# Altium schematic sheet [3] Sensor.SchDoc("[3] Sensor")
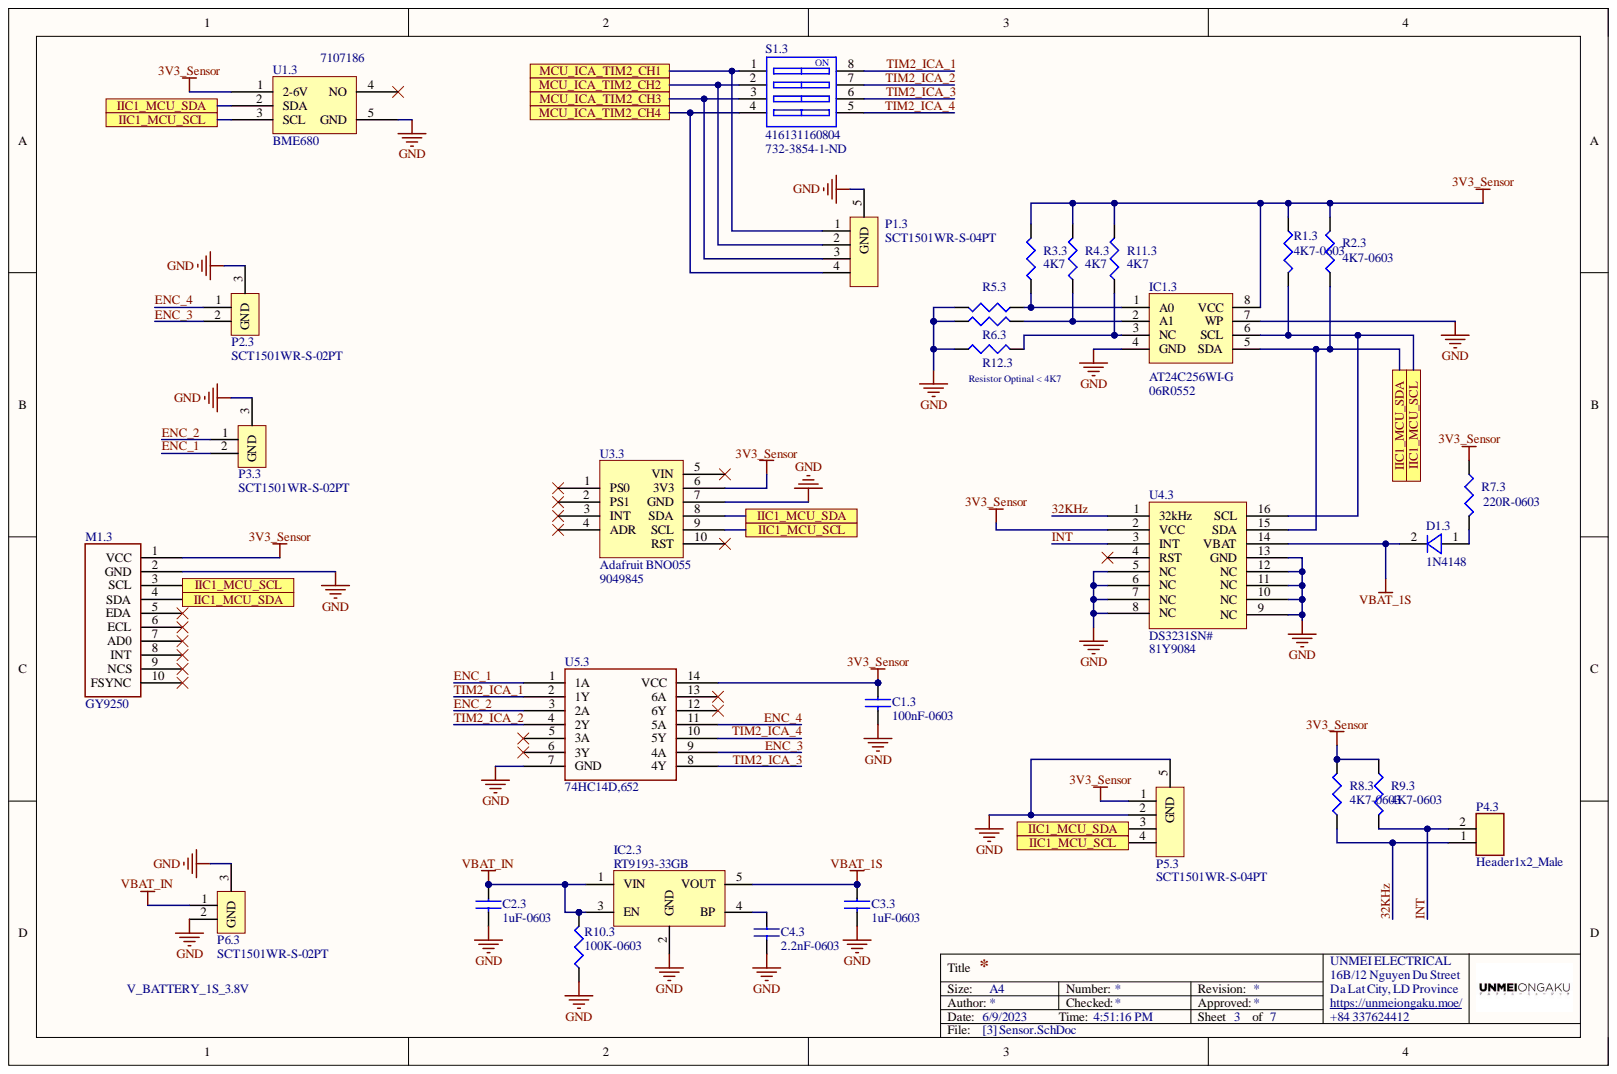
[diagram p0: [3] Sensor.SchDoc]

## Parts
C1.3  100nF-0603  [CAP0603]
C2.3  1uF-0603  [CAP0603]
C3.3  1uF-0603  [CAP0603]
C4.3  2.2nF-0603  [CAP0603]
D1.3  1N4148  [SOD-80]
IC1.3  AT24C256WI-G  Supplier Part Number 1: 06R0552  [SOIC-8]
IC2.3  RT9193-33GB  [SOT23-5]
M1.3  GY9250  [GY9250]
P1.3  SCT1501WR-S-04PT  [Connector ZH1.5 4P SMD]
P2.3  SCT1501WR-S-02PT  [Connector ZH1.5 2P SMD]
P3.3  SCT1501WR-S-02PT  [Connector ZH1.5 2P SMD]
P4.3  Header1x2_Male  [HDR1x2_2.54_MALE]
P5.3  SCT1501WR-S-04PT  [Connector ZH1.5 4P SMD]
P6.3  SCT1501WR-S-02PT  [Connector ZH1.5 2P SMD]
R1.3  4K7-0603  [RES0603 - 3D]
R2.3  4K7-0603  [RES0603 - 3D]
R3.3  4K7  [RES0603 - 3D]
R4.3  4K7  [RES0603 - 3D]
R5.3  70R  [RES0805]  (value not drawn)
R6.3  70R  [RES0805]  (value not drawn)
R7.3  220R-0603  [RES0603 - 3D]
R8.3  4K7-0603  [RES0603 - 3D]
R9.3  4K7-0603  [RES0603 - 3D]
R10.3  100K-0603  [RES0603 - 3D]
R11.3  4K7  [RES0603 - 3D]
R12.3  0RP  [RES0805]  (value not drawn)
S1.3  416131160804  Supplier Part Number 1: 732-3854-1-ND  [DIP Switches SMD 1.27mm 4P]
U1.3  BME680  Supplier Part Number 1: 7107186  [BME680]
U3.3  Adafruit BNO055  Manufacturer Part Number: BNO055  Supplier Part Number 1: 9049845  [Adafruit BNO055]
U4.3  DS3231SN#  Supplier Part Number 1: 81Y9084  [SOIC-16W]
U5.3  74HC14D,652  [SOT108-1_NEX]

## Ports
IIC1_MCU_SCL, IIC1_MCU_SDA, MCU_ICA_TIM2_CH1, MCU_ICA_TIM2_CH2, MCU_ICA_TIM2_CH3, MCU_ICA_TIM2_CH4

## Nets
3V3_Sensor: C1.3.1 IC1.3.8(VCC) M1.3.1(VCC) P5.3.1 R1.3.1 R2.3.2 R3.3.2 R4.3.2 R7.3.1 R8.3.2 R9.3.1 R11.3.2 U1.3.1(2-6V) U3.3.6(3V3) U4.3.2(VCC) U5.3.14(VCC)
GND: C1.3.2 C2.3.2 C3.3.2 C4.3.2 IC1.3.4(GND) IC1.3.7(WP) IC2.3.2(GND) M1.3.2(GND) P1.3.5(GND) P2.3.3 P3.3.3 P5.3.2 P5.3.5(GND) P6.3.2 P6.3.3 R5.3.2 R6.3.1 R10.3.1 R12.3.1 U1.3.5(GND) U3.3.7(GND) U4.3.5(NC) U4.3.6(NC) U4.3.7(NC) U4.3.8(NC) U4.3.9(NC) U4.3.10(NC) U4.3.11(NC) U4.3.12(NC) U4.3.13(GND) U5.3.7(GND)
VBAT_1S: C3.3.1 D1.3.2 IC2.3.5(VOUT) U4.3.14(VBAT)
VBAT_IN: C2.3.1 IC2.3.1(VIN) IC2.3.3(EN) P6.3.1 R10.3.2
32KHz: P4.3.1 R8.3.1 U4.3.1(32kHz)
ENC_1: P3.3.2 U5.3.1(1A)
ENC_2: P3.3.1 U5.3.3(2A)
ENC_3: P2.3.2 U5.3.9(4A)
ENC_4: P2.3.1 U5.3.11(5A)
IIC1_MCU_SCL: IC1.3.6(SCL) M1.3.3(SCL) P5.3.4 R1.3.2 U1.3.3(SCL) U3.3.9(SCL) U4.3.16(SCL) ; port IIC1_MCU_SCL
IIC1_MCU_SDA: IC1.3.5(SDA) M1.3.4(SDA) P5.3.3 R2.3.1 U1.3.2(SDA) U3.3.8(SDA) U4.3.15(SDA) ; port IIC1_MCU_SDA
INT: P4.3.2 R9.3.2 U4.3.3(INT)
MCU_ICA_TIM2_CH1: P1.3.1 S1.3.1 ; port MCU_ICA_TIM2_CH1
MCU_ICA_TIM2_CH2: P1.3.2 S1.3.2 ; port MCU_ICA_TIM2_CH2
MCU_ICA_TIM2_CH3: P1.3.3 S1.3.3 ; port MCU_ICA_TIM2_CH3
MCU_ICA_TIM2_CH4: P1.3.4 S1.3.4 ; port MCU_ICA_TIM2_CH4
TIM2_ICA_1: S1.3.8 U5.3.2(1Y)
TIM2_ICA_2: S1.3.7 U5.3.4(2Y)
TIM2_ICA_3: S1.3.6 U5.3.8(4Y)
TIM2_ICA_4: S1.3.5 U5.3.10(5Y)
NetC4.3_1: C4.3.1 IC2.3.4(BP)
NetD1.3_1: D1.3.1 R7.3.2
NetIC1.3_1: IC1.3.1(A0) R3.3.1 R5.3.1
NetIC1.3_2: IC1.3.2(A1) R4.3.1 R6.3.2
NetIC1.3_3: IC1.3.3(NC) R11.3.1 R12.3.2

Not connected: M1.3 5(EDA) 6(ECL) 7(AD0) 8(INT) 9(NCS) 10(FSYNC); U1.3 4(NO); U3.3 1(PS0) 2(PS1) 3(INT) 4(ADR) 5(VIN) 10(RST); U4.3 4(RST); U5.3 5(3A) 6(3Y) 12(6Y) 13(6A)

## Library data of the parts
C1.3 = CC0603KRX7R9BB104: CAP CER 0.1UF 50V X7R 0603; Manufacturer 1: Yageo; Capacitance: 0.1µF; Category 1: Capacitors; Tolerance: ±10%; Value: 100nF; Applications: General Purpose; Component Kind: Standard; ComponentLink1Description: http://www.yageo.com/documents/recent/UPY-GPHC_X7R_6.3V-to-50V_18.pdf; ComponentLink1URL: http://www.yageo.com/documents/recent/UPY-GPHC_X7R_6.3V-to-50V_18.pdf; Description 1: CAP CER 0.1UF 50V X7R 0603; Failure Rate: -; Features: -; Height - Seated (Max): -; Lead Spacing: -; Lead Style: -; Manufacturer Part Number 1: CC0603KRX7R9BB104; Mounting Type: Surface Mount, MLCC; Operating Temperature: -55°C ~ 125°C; Package / Case: 0603 (1608 Metric); Packaging: Cut Tape (CT); Part Status: Active; Pricing 1: 1=0.1, 10=0.031, 100=0.014, 500=0.00998, 1000=0.00783 (USD); Ratings: -; RoHS 1: RoHS Compliant; Size / Dimension: 0.063" L x 0.031" W (1.60mm x 0.80mm); Stock 1: 4411645; Supplier 1: Digi-Key; Supplier Part Number 1: 311-1344-1-ND; Temperature Coefficient: X7R; Thickness (Max): 0.035" (0.90mm); Voltage - Rated: 50V; Library Name: Minh_Lib.SchLib
C2.3 C3.3 = CC0603KRX7R7BB105: CAP CER 1UF 16V X7R 0603; Manufacturer 1: Yageo; Capacitance: 1µF; Category 1: Capacitors; Tolerance: ±10%; Applications: General Purpose; Component Kind: Standard; ComponentLink1Description: http://www.yageo.com/documents/recent/UPY-GPHC_X7R_6.3V-to-50V_18.pdf; ComponentLink1URL: http://www.yageo.com/documents/recent/UPY-GPHC_X7R_6.3V-to-50V_18.pdf; Description 1: CAP CER 1UF 16V X7R 0603; Failure Rate: -; Features: -; Height - Seated (Max): -; Lead Spacing: -; Lead Style: -; Manufacturer Part Number 1: CC0603KRX7R7BB105; Mounting Type: Surface Mount, MLCC; Operating Temperature: -55°C ~ 125°C; Package / Case: 0603 (1608 Metric); Packaging: Cut Tape (CT); Part Status: Active; Pricing 1: 1=0.1, 10=0.051, 100=0.0227, 500=0.0162, 1000=0.01274 (USD); Ratings: -; RoHS 1: RoHS Compliant; Size / Dimension: 0.063" L x 0.031" W (1.60mm x 0.80mm); Stock 1: 274580; Supplier 1: Digi-Key; Supplier Part Number 1: 311-1446-1-ND; Temperature Coefficient: X7R; Thickness (Max): 0.035" (0.90mm); Value: 1uF; Voltage - Rated: 16V; Library Name: Minh_Lib.SchLib
C4.3 = CC0603KRX7R9BB222: Multilayer Ceramic Capacitors MLCC - SMD/SMT 2200PF 50V 10% 0603; Brand: Yageo; Capacitance - nF: 2.2 nF; Capacitance - uF: 0.0022 uF; Class: Class 2; Dielectric: X7R; Type: General Purpose and High Capacitance Surface Mount Ceramic Multilayer Capacitor; Value: 2.2nF; Voltage Rating DC: 50 VDC; Capacitance: 2200 pF; Case Code - in: 0603; Case Code - mm: 1608; Category: Multilayer Ceramic Capacitors MLCC - SMD/SMT; Component Kind: Standard; ComponentLink1Description: https://www.mouser.com/datasheet/2/447/UPY-GPHC_X7R_6.3V-to-50V_18-1154002.pdf; ComponentLink1URL: https://www.mouser.com/datasheet/2/447/UPY-GPHC_X7R_6.3V-to-50V_18-1154002.pdf; Height: 0.8 mm; Length: 1.6 mm; Manufacturer: Yageo; Manufacturer Part Number: CC0603KRX7R9BB222; Maximum Operating Temperature: + 125 C; Minimum Operating Temperature: - 55 C; Minimum Order Quantity: 1; Package / Case: 0603 (1608 metric); Packaging: Cut Tape; Pricing 1: 1=0.100, 10=0.090, 100=0.070, 1000=0.065, 4000=0.010, 8000=0.009 (USD); Product: General Type MLCCs; Product Type: Ceramic Capacitors; Reeling: True; RoHS: RoHS Compliant; RoHS - Mouser: Y; Series: CC; Standard Pack Qty: 4000; Stock 1: 167669; Subcategory: Capacitors; Supplier 1: Mouser; Supplier Part Number 1: 603-C0603KRX7R9BB222; Termination: Standard; Termination Style: SMD/SMT; Tolerance: 10 %; Width: 0.8 mm; Library Name: Minh_Lib.SchLib
D1.3 = 1N4148: Rectifier Diode Small Signal Switching 100V 0.2A 4ns Automotive 2-Pin DO-35 Ammo; Manufacturer: NTE Electronics; Capacitance: 4pF; Current Rating: 200mA; Element Configuration: Single; Forward Current: 300mA; Forward Voltage: 1V; Max Forward Surge Current (Ifsm): 1A; Max Repetitive Reverse Voltage (Vrrm): 75V; Polarity: Standard; Power Dissipation: 500mW; Case/Package: DO-35; Category: Rectifier Diodes; Component Kind: Standard; ComponentLink1Description: https://datasheet.ciiva.com/7624/1n4148-4448-7624426.pdf?src-supplier=Element14; ComponentLink1URL: https://datasheet.ciiva.com/7624/1n4148-4448-7624426.pdf?src-supplier=Element14; Diameter: 2mm; Lead Free: Lead Free; Length: 4mm; Manufacturer Part Number: 1N4148; Max Operating Temperature: 175°C; Min Operating Temperature: -65°C; Mount: Through Hole; Number of Pins: 2; Output Current: 200mA; Packaging: Tape and Reel; Pricing 1: 1=0.08800 (USD); Reverse Recovery Time: 4ns; RoHS: Yes; RoHS Compliant: Yes; Stock 1: 5690; Supplier 1: Allied Electronics; Supplier Part Number 1: 70214727; Voltage Rating (DC): 100V; Library Name: Minh_Lib.SchLib
IC1.3 = AT24C256WI-G: CAT24C256 Series 256 Kb (32K X 8)  1.8 V - 5.5 V I2C CMOS Serial EEPROM - SOIC-8; Manufacturer: ON Semiconductor; Manufacturer Part Number: CAT24C256WI-G; Access Time: 500ns; Access Time-Max: 900ns; Density: 256Kb; Frequency: 400kHz; Interface: I2C,Serial; Max Frequency: 100kHz; Max Supply Voltage: 5.5V; Memory Type: Non-Volatile,EEPROM; Min Supply Voltage: 1.8V; Nominal Supply Current: 3mA; Operating Supply Voltage: 5V; Organization: 32KX8; Write Cycle Time-Max (tWC): 5ms; Write Protection: YES; Case/Package: SOIC; Category: EEPROM; Component Kind: Standard; ComponentLink1Description: https://datasheet.ciiva.com/1166/cat24c256-d-1166416.pdf?src-supplier=Newark; ComponentLink1URL: https://datasheet.ciiva.com/1166/cat24c256-d-1166416.pdf?src-supplier=Newark; ComponentLink2Description: https://datasheet.ciiva.com/15123/getdatasheetpartid-257691-15123320.pdf?src-supplier=Verical; ComponentLink2URL: https://datasheet.ciiva.com/15123/getdatasheetpartid-257691-15123320.pdf?src-supplier=Verical; ComponentLink3Description: https://datasheet.ciiva.com/5700/cat24c256-d-5700793.pdf?src-supplier=Farnell; ComponentLink3URL: https://datasheet.ciiva.com/5700/cat24c256-d-5700793.pdf?src-supplier=Farnell; ComponentLink4Description: https://datasheet.ciiva.com/8072/cat24c256-d-8072141.pdf?src-supplier=Element14; ComponentLink4URL: https://datasheet.ciiva.com/8072/cat24c256-d-8072141.pdf?src-supplier=Element14; ComponentLink5Description: https://datasheet.ciiva.com/4859/cat24c256-d-105771-4859399.pdf?src-supplier=Mouser; ComponentLink5URL: https://datasheet.ciiva.com/4859/cat24c256-d-105771-4859399.pdf?src-supplier=Mouser; ComponentLink6Description: https://datasheet.ciiva.com/3185/cat24c256-d-3185116.pdf?src-supplier=Avnet; ComponentLink6URL: https://datasheet.ciiva.com/3185/cat24c256-d-3185116.pdf?src-supplier=Avnet; ComponentLink7Description: https://datasheet.ciiva.com/16456/cat24c256-d-16456381.pdf?src-supplier=Future+Electronics; ComponentLink7URL: https://datasheet.ciiva.com/16456/cat24c256-d-16456381.pdf?src-supplier=Future+Electronics; ComponentLink8Description: https://datasheet.ciiva.com/1912/cat24c256-d-1912247.pdf?src-supplier=Digi-Key; ComponentLink8URL: https://datasheet.ciiva.com/1912/cat24c256-d-1912247.pdf?src-supplier=Digi-Key; Contact Plating: Gold; Lead Free: Lead Free; Max Operating Temperature: 85°C; Memory Size: 256Kb; Min Operating Temperature: -40°C; Mount: Surface Mount; Number of Pins: 8; Package Quantity: 100; Packaging: Rail/Tube; Radiation Hardening: No; Reach SVHC Compliant: Yes; RoHS: Yes; RoHS Compliant: Yes; Series: -; Supplier 1: Newark; Supply Current: 3mA; Supply Voltage-Nom: 5.5V; Library Name: Minh_Lib.SchLib
IC2.3 = RT9193-33GB: IC REG LDO 3.3V 0.3A SOT23-5; Component Kind: Standard; Library Name: Minh_Lib.SchLib
P4.3 = HDR1x2_2.54_MALE: Header1x2_2.54_MALE; Component Kind: Standard; Library Name: Minh_Lib.SchLib
R1.3 R2.3 R8.3 R9.3 = RC0603JR-074K7L: RES SMD 4.7K OHM 5% 1/10W 0603; Manufacturer: Yageo; Power (Watts): 0.1W, 1/10W; Temperature Coefficient: ±100ppm/°C; Value: 4K7; Category: Resistors; Component Kind: Standard; ComponentLink1Description: http://www.yageo.com/documents/recent/PYu-RC_Group_51_RoHS_L_7.pdf; ComponentLink1URL: http://www.yageo.com/documents/recent/PYu-RC_Group_51_RoHS_L_7.pdf; Composition: Thick Film; Failure Rate: -; Features: Moisture Resistant; Height - Seated (Max): 0.022" (0.55mm); Manufacturer Part Number: RC0603JR-074K7L; Operating Temperature: -55°C ~ 155°C; Package / Case: 0603 (1608 Metric); Packaging: Cut Tape (CT); Part Status: Active; Pricing 1: 1=0.1, 10=0.011, 100=0.0046, 1000=0.00207, 2500=0.0018 (USD); RoHS: RoHS Compliant; Size / Dimension: 0.063" L x 0.032" W (1.60mm x 0.80mm); Stock 1: 4925196; Supplier 1: Digi-Key; Supplier Device Package: 0603; Supplier Part Number 1: 311-4.7KGRCT-ND; Tolerance: ±5%; Library Name: Minh_Lib.SchLib
R3.3 R4.3 R11.3 = RC0603JR-074K7L: RES SMD 4.7K OHM 5% 1/10W 0603; Manufacturer: Yageo; Power (Watts): 0.1W, 1/10W; Temperature Coefficient: ±100ppm/°C; Category: Resistors; Component Kind: Standard; ComponentLink1Description: http://www.yageo.com/documents/recent/PYu-RC_Group_51_RoHS_L_7.pdf; ComponentLink1URL: http://www.yageo.com/documents/recent/PYu-RC_Group_51_RoHS_L_7.pdf; Composition: Thick Film; Failure Rate: -; Features: Moisture Resistant; Height - Seated (Max): 0.022" (0.55mm); Manufacturer Part Number: RC0603JR-074K7L; Operating Temperature: -55°C ~ 155°C; Package / Case: 0603 (1608 Metric); Packaging: Cut Tape (CT); Part Status: Active; Pricing 1: 1=0.1, 10=0.011, 100=0.0046, 1000=0.00207, 2500=0.0018 (USD); RoHS: RoHS Compliant; Size / Dimension: 0.063" L x 0.032" W (1.60mm x 0.80mm); Stock 1: 4925196; Supplier 1: Digi-Key; Supplier Device Package: 0603; Supplier Part Number 1: 311-4.7KGRCT-ND; Tolerance: ±5%; Value: 4K7; Library Name: Minh_Lib.SchLib
R5.3 R6.3 R12.3 = RC0805JR-070RP: Res Jumper Thick Film 0805 0 Ohm 5% 1/8W Molded SMD Paper T/R; Manufacturer: Yageo; Max Power Dissipation: 125mW; Resistance: 0mR; Case Code (Imperial): 0805; Case Code (Metric): 2012; Case/Package: 0805; Category: Chip SMD Resistors; Component Kind: Standard; Features: Moisture Resistant; Height: 0.024inch; Length: 0.079inch; Manufacturer Part Number: RC0805JR-070RP; Max Operating Temperature: 155°C; Min Operating Temperature: -55°C; Number of Terminations: 2; Packaging: Tape and Reel; Pricing 1: 5000=0.00800, 10000=0.00690, 25000=0.00590, 50000=0.00480 (USD); Resistor Type: Thick Film; RoHS: Yes; Stock 1: 10000; Supplier 1: Arrow Electronics; Supplier Part Number 1: RC0805JR-070RP; Width: 0.049inch; Library Name: Minh_Lib.SchLib
R7.3 = RC0603JR-07220RL: RES SMD 220 OHM 5% 1/10W 0603; Manufacturer 1: Yageo; Category 1: Resistors; Power (Watts): 0.1W, 1/10W; Resistance (Ohms): 220; Temperature Coefficient: ±100ppm/°C; Value: 220R; Component Kind: Standard; ComponentLink1Description: http://www.yageo.com/documents/recent/PYu-RC_Group_51_RoHS_L_7.pdf; ComponentLink1URL: http://www.yageo.com/documents/recent/PYu-RC_Group_51_RoHS_L_7.pdf; Composition: Thick Film; Description 1: RES SMD 220 OHM 5% 1/10W 0603; Failure Rate: -; Features: Moisture Resistant; Height - Seated (Max): 0.022" (0.55mm); Manufacturer Part Number 1: RC0603JR-07220RL; Operating Temperature: -55°C ~ 155°C; Package / Case: 0603 (1608 Metric); Packaging: Cut Tape (CT); Part Status: Active; Pricing 1: 1=0.1, 10=0.011, 100=0.0044, 1000=0.00198, 2500=0.00172 (USD); RoHS 1: RoHS Compliant; Size / Dimension: 0.063" L x 0.031" W (1.60mm x 0.80mm); Stock 1: 1346821; Supplier 1: Digi-Key; Supplier Device Package: 0603; Supplier Part Number 1: 311-220GRCT-ND; Tolerance: ±5%; Library Name: Minh_Lib.SchLib
R10.3 = RC0603JR-07100KL: RES SMD 100K OHM 5% 1/10W 0603; Manufacturer 1: Yageo; Category 1: Resistors; Power (Watts): 0.1W, 1/10W; Temperature Coefficient: ±100ppm/°C; Component Kind: Standard; ComponentLink1Description: http://www.yageo.com/documents/recent/PYu-RC_Group_51_RoHS_L_7.pdf; ComponentLink1URL: http://www.yageo.com/documents/recent/PYu-RC_Group_51_RoHS_L_7.pdf; Composition: Thick Film; Description 1: RES SMD 100K OHM 5% 1/10W 0603; Failure Rate: -; Features: Moisture Resistant; Height - Seated (Max): 0.022" (0.55mm); Manufacturer Part Number 1: RC0603JR-07100KL; Operating Temperature: -55°C ~ 155°C; Package / Case: 0603 (1608 Metric); Packaging: Cut Tape (CT); Part Status: Active; Pricing 1: 1=0.1, 10=0.011, 100=0.0044, 1000=0.00198, 2500=0.00172 (USD); Resistance (Ohms): 100k; RoHS 1: RoHS Compliant; Size / Dimension: 0.063" L x 0.031" W (1.60mm x 0.80mm); Stock 1: 3591837; Supplier 1: Digi-Key; Supplier Device Package: 0603; Supplier Part Number 1: 311-100KGRCT-ND; Tolerance: ±5%; Value: 100K; Library Name: Minh_Lib.SchLib
S1.3 = 416131160804: DIP Switch Dimensions L x W x H: 5.6 x 2 mm Series: WS-DISV L: 6.12 mm Poles: 4; Manufacturer: Wurth Electronics; Actuator Type: Slide (Standard); Body Material: Polyphenylene,Polyphthalamide; Contact Current Rating: 25mA; Contact Resistance: 50mR; Electrical Life: 1000Cycles; Insulation Resistance: 100MR; Max Voltage Rating (DC): 24V; Mechanical Life: 2000Cycles; Number of Circuits: 4; Pitch: 0.05inch; Throw Configuration: SPST; Washable: Yes; Category: DIP Switches; Component Kind: Standard; ComponentLink1Description: https://datasheet.ciiva.com/30467/0900766b812902d9-30467107.pdf?src-supplier=RSComponents; ComponentLink1URL: https://datasheet.ciiva.com/30467/0900766b812902d9-30467107.pdf?src-supplier=RSComponents; ComponentLink2Description: https://datasheet.ciiva.com/1528/4161311608xx-1528928.pdf?src-supplier=Element14; ComponentLink2URL: https://datasheet.ciiva.com/1528/4161311608xx-1528928.pdf?src-supplier=Element14; ComponentLink3Description: https://datasheet.ciiva.com/2863/4161311608xx-2863702.pdf?src-supplier=Digi-Key; ComponentLink3URL: https://datasheet.ciiva.com/2863/4161311608xx-2863702.pdf?src-supplier=Digi-Key; ComponentLink4Description: https://datasheet.ciiva.com/2863/4161311608xx-2863701.pdf?src-supplier=Digi-Key; ComponentLink4URL: https://datasheet.ciiva.com/2863/4161311608xx-2863701.pdf?src-supplier=Digi-Key; ComponentLink5Description: https://datasheet.ciiva.com/1625/4161311608xx-1625344.pdf?src-supplier=Farnell; ComponentLink5URL: https://datasheet.ciiva.com/1625/4161311608xx-1625344.pdf?src-supplier=Farnell; Contact Material: Copper Alloy,Gold; Contact Plating: Gold; Current Rating: 25mA; Height: 2mm; Lead Free: Lead Free; Length: 0.241inch; Manufacturer Part Number: 416131160804; Max Operating Temperature: 85°C; Min Operating Temperature: -40°C; Mount: Surface Mount; Number of Positions: 4; Package Quantity: 1; Packaging: Cut Tape; Pricing 1: 1=5.25000, 10=5.08200, 50=4.81400, 100=4.27900, 500=4.01200 (USD); Reach SVHC Compliant: Yes; RoHS: Yes; RoHS Compliant: Yes; Series: WS-DISV; Stock 1: 13030; Supplier 1: Digi-Key; Termination: Gull Wing; Voltage Rating (DC): 24V; Width: 5.6mm; Library Name: Minh_Lib.SchLib
U1.3 = BME680: Pimoroni.com - Pressure, Temperature and Humidity Sensor Digital Output 1.8V 8-Pin LGA; Manufacturer: Bosch Sensortec; Output Type: SPI,I2C; Category: Uncategorized; Component Kind: Standard; ComponentLink1Description: https://ae-bst.resource.bosch.com/media/_tech/media/application_notes/BST-BME680-HS000-05.pdf?src-supplier=Digi-Key; ComponentLink1URL: https://ae-bst.resource.bosch.com/media/_tech/media/application_notes/BST-BME680-HS000-05.pdf?src-supplier=Digi-Key; ComponentLink2Description: https://ae-bst.resource.bosch.com/media/_tech/media/product_flyer/BST-BME680-FL000.pdf?src-supplier=Digi-Key; ComponentLink2URL: https://ae-bst.resource.bosch.com/media/_tech/media/product_flyer/BST-BME680-FL000.pdf?src-supplier=Digi-Key; Manufacturer Part Number: BME680; Max Operating Temperature: 85°C; Min Operating Temperature: -40°C; Pricing 1: 1=9.84000, 20=8.81000, 125=8.18000, 500=7.71000, 3000=7.09000 (USD); RoHS: No; Sensor Type: Temperature; Stock 1: 64; Supplier 1: Future Electronics; Library Name: Minh_Lib.SchLib
U3.3 = Adafruit BNO055: BNO055 Series 1.7 to 3.6 V Intelligent 9-Axis Absolute Orientation Sensor-LGA-28; Manufacturer: Bosch; Output Type: I2C; Sensor Type: Accelerometer,Gyroscope,Magnetometer,3 Axis; Case/Package: LGA; Category: Inertial Measurement Units (IMUs); Component Kind: Standard; ComponentLink1Description: https://ae-bst.resource.bosch.com/media/_tech/media/application_notes/BST-BNO055-HS000.pdf ?src-supplier=Digi-Key; ComponentLink1URL: https://ae-bst.resource.bosch.com/media/_tech/media/application_notes/BST-BNO055-HS000.pdf ?src-supplier=Digi-Key; Lead Free: Lead Free; Max Operating Temperature: 85°C; Min Operating Temperature: -40°C; Mount: Surface Mount; Packaging: Tape and Reel; Pricing 1: 4000=7.70000 (USD); RoHS: No; Supplier 1: Future Electronics; Library Name: Minh_Lib.SchLib
U4.3 = DS3231SN#: DS3231 Series 5.5 V Extremely Accurate I2C-Integrated RTC/TCXO/Crystal - SOIC-16; Manufacturer: Maxim; Date Format: YY-MM-DD-dd; Frequency: 32.768kHz; Interface: I2C,Serial,2-Wire; Logic Function: Clock; Max Supply Voltage: 5.5V; Min Supply Voltage: 2.3V; Number of Outputs: 1; Operating Supply Voltage: 3.3V; Time Format: HH:MM:SS; Case/Package: SOIC; Category: Real Time Clocks; Component Kind: Standard; ComponentLink1Description: https://datasheet.ciiva.com/1139/1675711-1139210.pdf?src-supplier=Element14; ComponentLink1URL: https://datasheet.ciiva.com/1139/1675711-1139210.pdf?src-supplier=Element14; ComponentLink2Description: https://datasheet.ciiva.com/23867/45724-23867216.pdf?src-supplier=Newark; ComponentLink2URL: https://datasheet.ciiva.com/23867/45724-23867216.pdf?src-supplier=Newark; ComponentLink3Description: https://datasheet.ciiva.com/1146/ds3231-1146707.pdf?src-supplier=Newark; ComponentLink3URL: https://datasheet.ciiva.com/1146/ds3231-1146707.pdf?src-supplier=Newark; ComponentLink4Description: https://datasheet.ciiva.com/1240/ds3231-ds3231s-1240689.pdf?src-supplier=Digi-Key; ComponentLink4URL: https://datasheet.ciiva.com/1240/ds3231-ds3231s-1240689.pdf?src-supplier=Digi-Key; ComponentLink5Description: https://datasheet.ciiva.com/4772/ds3231-102175-4772091.pdf?src-supplier=Mouser; ComponentLink5URL: https://datasheet.ciiva.com/4772/ds3231-102175-4772091.pdf?src-supplier=Mouser; ComponentLink6Description: https://datasheet.ciiva.com/12291/ds3231-102175-12291423.pdf?src-supplier=Mouser; ComponentLink6URL: https://datasheet.ciiva.com/12291/ds3231-102175-12291423.pdf?src-supplier=Mouser; ComponentLink7Description: https://datasheet.ciiva.com/14244/ds3231-14244059.pdf?src-supplier=Avnet; ComponentLink7URL: https://datasheet.ciiva.com/14244/ds3231-14244059.pdf?src-supplier=Avnet; Height: 2.35mm; Lead Free: Lead Free; Length: 10.5mm; Manufacturer Part Number: DS3231SN#; Max Operating Temperature: 85°C; Min Operating Temperature: -40°C; Mount: Surface Mount; Number of Pins: 16; Package Quantity: 46; Packaging: Bulk; Pricing 1: 1=11.12000, 10=10.69000, 25=10.25000, 138=9.03000, 276=8.59000, 506=8.31000 (USD); Radiation Hardening: No; RoHS: Yes; RoHS Compliant: Yes; Series: DS3231SN; Supplier 1: Newark; Termination: SMD/SMT; Weight: 0.023492oz; Width: 7.6mm; Library Name: Minh_Lib.SchLib
U5.3 = 74HC14D,652: SourceLibrary: Nexperia_2018-05-2018; Vendor: Nexperia; Component Kind: Standard; Local Supplier: thegioiic; Local Supplier Link: https://www.thegioiic.com/products/74hc14d; Manufacturer_Part_Number: 74HC14D,652; RefDes: RefDes; Type: DEV; Value: Value; Copyright: Copyright (C) 2016 Accelerated Designs. All rights reserved; Library Name: Minh_Lib.SchLib
M1.3 = GY9250: Component Kind: Standard; Local Supplier: thegioiic; Local Supplier Link: https://www.thegioiic.com/products/gy-9250-mach-cam-bien-9dof; Library Name: Minh_Lib.SchLib
P1.3 P5.3 = SCT1501WR-S-04PT: Component Kind: Standard; Library Name: Minh_Lib.SchLib
P2.3 P3.3 P6.3 = SCT1501WR-S-02PT: Component Kind: Standard; Library Name: Minh_Lib.SchLib
